# Altium schematic sheet DCI.SchDoc
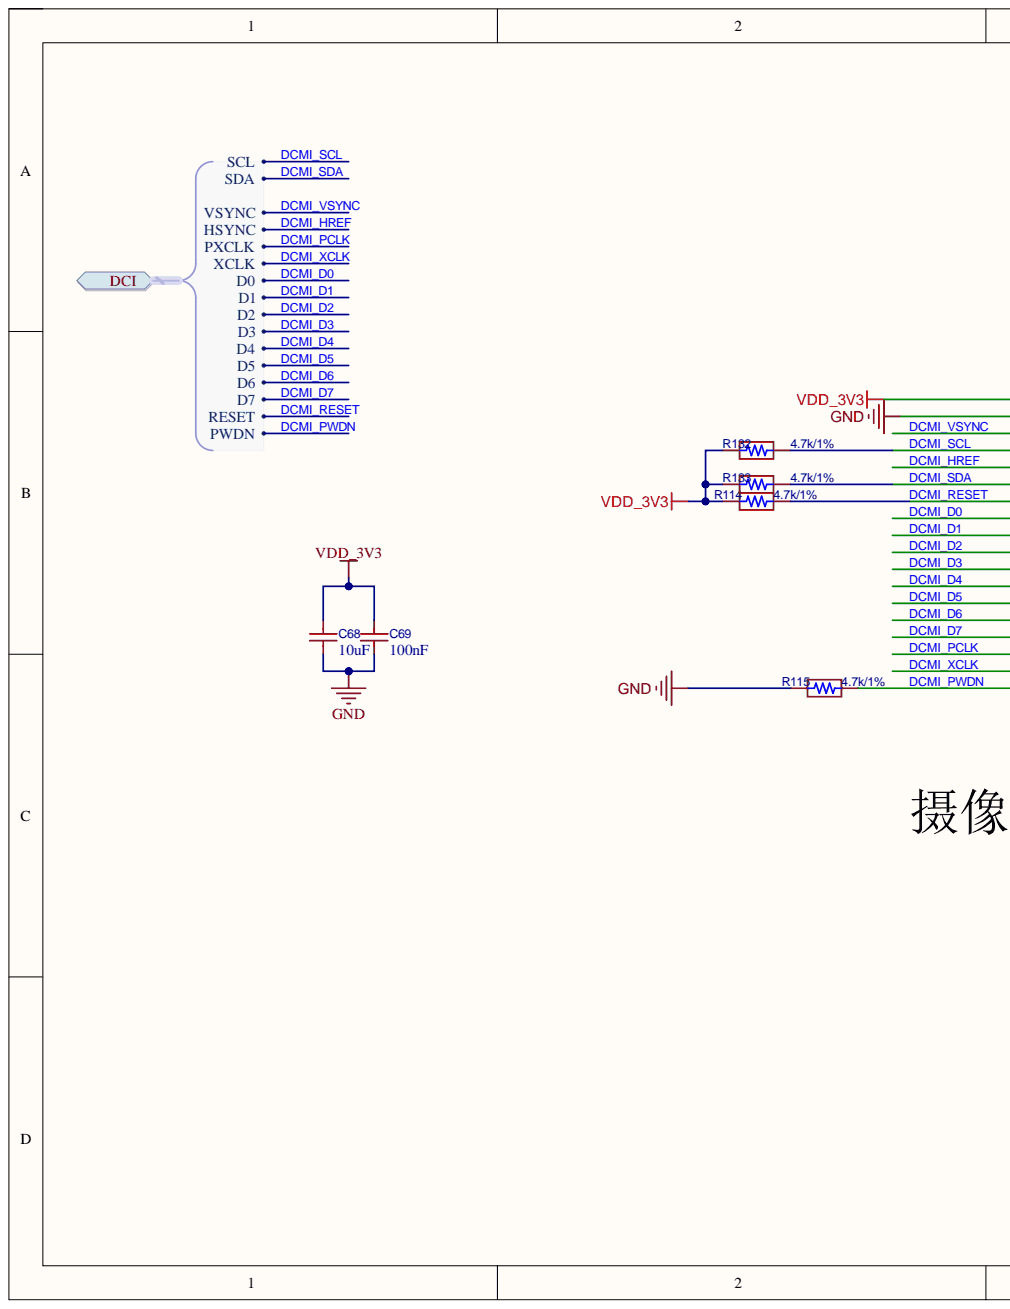
[diagram p0: DCI.SchDoc, left]
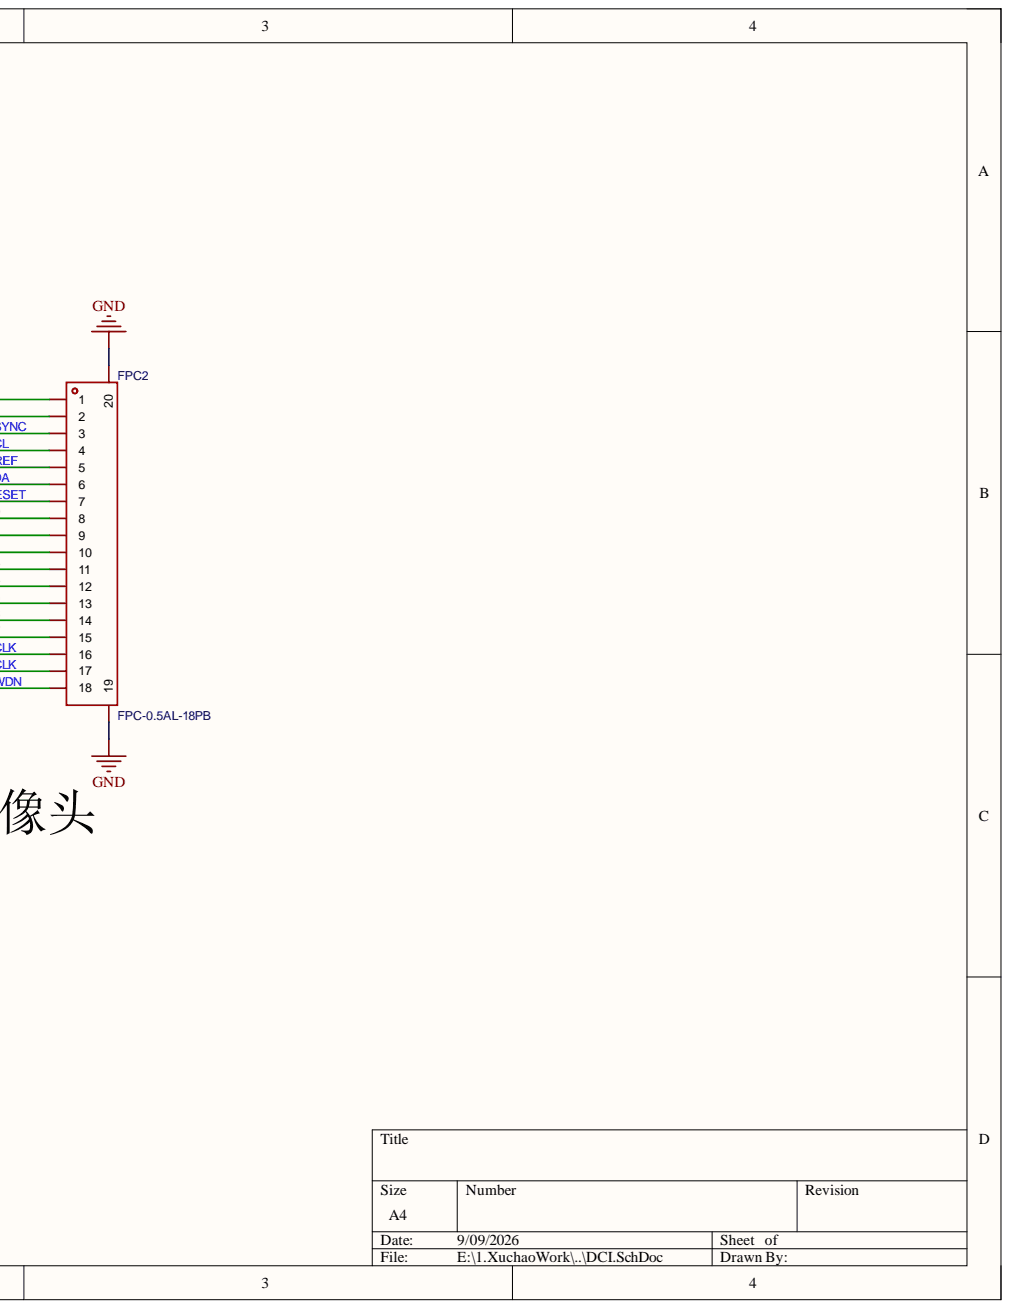
[diagram p1: DCI.SchDoc, right]

## Parts
C68  10uF  [C0603]
C69  100nF  [C0603]
FPC2  FPC-0.5AL-18PB  [FPC-SMD_18P-P0.50_XUNPU_FPC-0.5AL-18PB]
R114  4.7k/1%  [R0402]
R115  4.7k/1%  [R0402]
R132  4.7k/1%  [R0402]
R133  4.7k/1%  [R0402]

## Ports
DCI, DCI.D0, DCI.D1, DCI.D2, DCI.D3, DCI.D4, DCI.D5, DCI.D6, DCI.D7, DCI.HSYNC, DCI.PWDN, DCI.PXCLK, DCI.RESET, DCI.SCL, DCI.SDA, DCI.VSYNC, DCI.XCLK

## Nets
GND: C68.1 C69.1 FPC2.2 FPC2.19 FPC2.20 R115.2
VDD_3V3: C68.2 C69.2 FPC2.1 R114.2 R132.1 R133.1
DCMI_D0: FPC2.8 ; port DCI
DCMI_D1: FPC2.9 ; port DCI
DCMI_D2: FPC2.10 ; port DCI
DCMI_D3: FPC2.11 ; port DCI
DCMI_D4: FPC2.12 ; port DCI
DCMI_D5: FPC2.13 ; port DCI
DCMI_D6: FPC2.14 ; port DCI
DCMI_D7: FPC2.15 ; port DCI
DCMI_HREF: FPC2.5 ; port DCI
DCMI_PCLK: FPC2.16 ; port DCI
DCMI_PWDN: FPC2.18 R115.1 ; port DCI
DCMI_RESET: FPC2.7 R114.1 ; port DCI
DCMI_SCL: FPC2.4 R132.2 ; port DCI
DCMI_SDA: FPC2.6 R133.2 ; port DCI
DCMI_VSYNC: FPC2.3 ; port DCI
DCMI_XCLK: FPC2.17 ; port DCI

## Library data of the parts
C68 C69 = 0SMD_CAP: Symbol: CL10A106KP8NNNC; Component Kind: Standard; Library Name: CYKJ_LibPkg.IntLib
FPC2 = FPC-0.5AL-18PB: 锁定特性:抽屉式;触点类型:立贴;触点数量:18P;间距:0.5mm;安装方式:单侧触点/垂直;工作温度:-25℃~+85℃;; Manufacturer: XUNPU(讯普); AddInBom: yes; Current Rating (Max): 500mA; FFC, FCB Thickness: 0.3mm; Locking Feature: 抽屉式; Number of Contacts: 18P; Pitch: 0.5mm; Rated Voltage (Max): 50V; Component Kind: Standard; Contact Material: 磷青铜; Contact Plating: 锡; Contact Type: 立贴; ConvertToPcb: yes; Datasheet: https://item.szlcsc.com/datasheet/FPC-0.5AL-18PB/4012045.html; Device: FPC-0.5AL-18PB; FootprintName: FPC-SMD_18P-P0.50_XUNPU_FPC-0.5AL-18PB; Height Above Board: 4.5mm; JLCPCB Part Class: Extended Part; LCSC Part Name: 抽屉式 立贴 P数:18P 间距:0.5mm; ManufacturerPart: FPC-0.5AL-18PB; Mounting Type: 单侧触点/垂直; Operating Temperature: -25℃~+85℃; Supplier: LCSC; Supplier Footprint: SMD,P=0.5mm; SupplierPart: C3446049; Symbol: FPC-0.5AL-18PB; Library Name: CYKJ_LibPkg.IntLib
R114 R115 R132 R133 = Resisteors: RES_SMD; Component Kind: Standard; Library Name: CYKJ_library.SchLib
